# Altium schematic sheet [8]miscellaneous.SchDoc
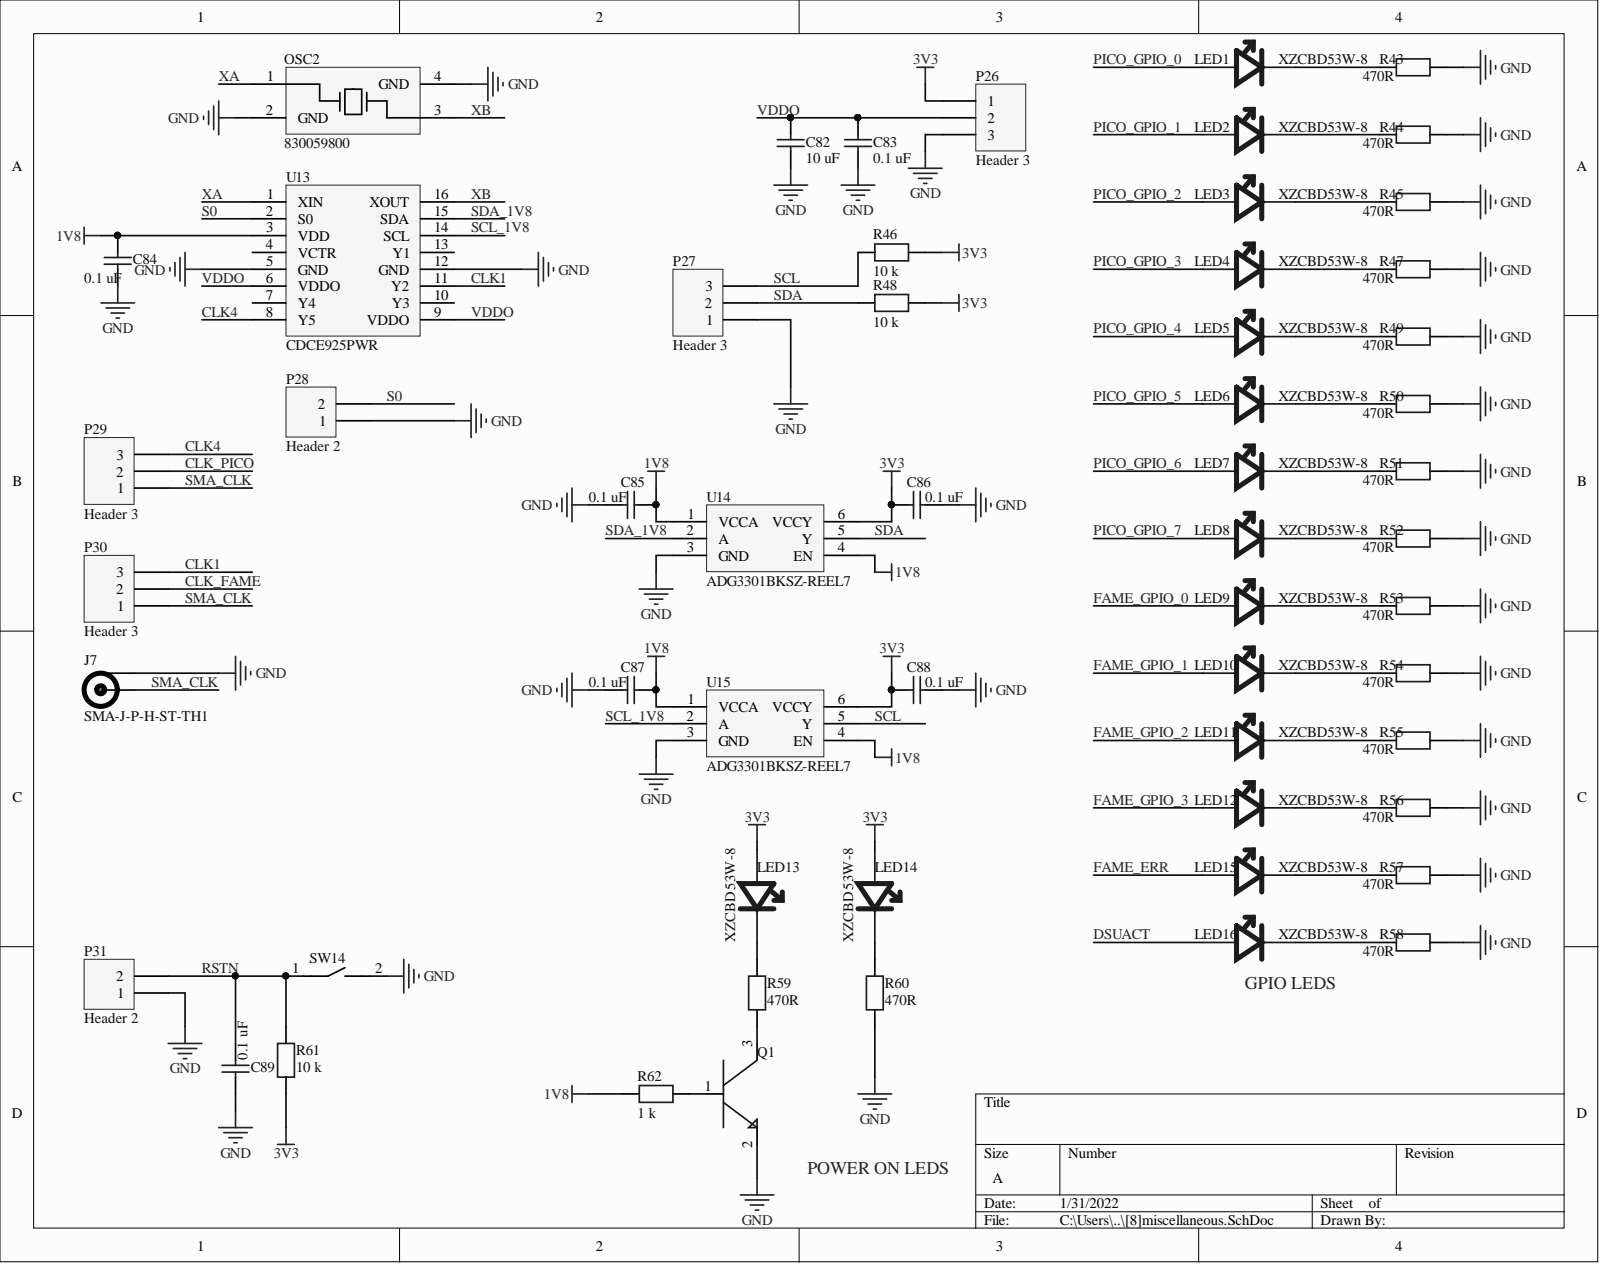
[diagram p0: [8]miscellaneous.SchDoc]

## Parts
C82  value 10 uF  [0603-CAP-ASM]  (hidden comment: Cap)
C83  value 0.1 uF  [0402-CAP-ASM]  (hidden comment: Cap)
C84  value 0.1 uF  [0402-CAP-ASM]  (hidden comment: Cap)
C85  value 0.1 uF  [0402-CAP-ASM]  (hidden comment: Cap)
C86  value 0.1 uF  [0402-CAP-ASM]  (hidden comment: Cap)
C87  value 0.1 uF  [0402-CAP-ASM]  (hidden comment: Cap)
C88  value 0.1 uF  [0402-CAP-ASM]  (hidden comment: Cap)
C89  value 0.1 uF  [0402-CAP-ASM]  (hidden comment: Cap)
J7  SMA-J-P-H-ST-TH1  [SMA-J-P-H-ST-TH1]
LED1  XZCBD53W-8  [0603-LED-ASM]
LED2  XZCBD53W-8  [0603-LED-ASM]
LED3  XZCBD53W-8  [0603-LED-ASM]
LED4  XZCBD53W-8  [0603-LED-ASM]
LED5  XZCBD53W-8  [0603-LED-ASM]
LED6  XZCBD53W-8  [0603-LED-ASM]
LED7  XZCBD53W-8  [0603-LED-ASM]
LED8  XZCBD53W-8  [0603-LED-ASM]
LED9  XZCBD53W-8  [0603-LED-ASM]
LED10  XZCBD53W-8  [0603-LED-ASM]
LED11  XZCBD53W-8  [0603-LED-ASM]
LED12  XZCBD53W-8  [0603-LED-ASM]
LED13  XZCBD53W-8  [0603-LED-ASM]
LED14  XZCBD53W-8  [0603-LED-ASM]
LED15  XZCBD53W-8  [0603-LED-ASM]
LED16  XZCBD53W-8  [0603-LED-ASM]
OSC2  830059800  [32X25 SMD-4 OSC]
P26  Header 3  [Header 1x3 male]
P27  Header 3  [Header 1x3 male]
P28  Header 2  [header 2]
P29  Header 3  [Header 1x3 male]
P30  Header 3  [Header 1x3 male]
P31  Header 2  [header 2]
Q1  CMPT3904G TR PBFREE  [Sot23-3]  (value not drawn)
R43  value 470R  [0402-RES-ASM]  (hidden comment: res)
R44  value 470R  [0402-RES-ASM]  (hidden comment: res)
R45  value 470R  [0402-RES-ASM]  (hidden comment: res)
R46  value 10 k  [0402-RES-ASM]  (hidden comment: res)
R47  value 470R  [0402-RES-ASM]  (hidden comment: res)
R48  value 10 k  [0402-RES-ASM]  (hidden comment: res)
R49  value 470R  [0402-RES-ASM]  (hidden comment: res)
R50  value 470R  [0402-RES-ASM]  (hidden comment: res)
R51  value 470R  [0402-RES-ASM]  (hidden comment: res)
R52  value 470R  [0402-RES-ASM]  (hidden comment: res)
R53  value 470R  [0402-RES-ASM]  (hidden comment: res)
R54  value 470R  [0402-RES-ASM]  (hidden comment: res)
R55  value 470R  [0402-RES-ASM]  (hidden comment: res)
R56  value 470R  [0402-RES-ASM]  (hidden comment: res)
R57  value 470R  [0402-RES-ASM]  (hidden comment: res)
R58  value 470R  [0402-RES-ASM]  (hidden comment: res)
R59  value 470R  [0402-RES-ASM]  (hidden comment: res)
R60  value 470R  [0402-RES-ASM]  (hidden comment: res)
R61  value 10 k  [0402-RES-ASM]  (hidden comment: res)
R62  value 1 k  [0402-RES-ASM]  (hidden comment: res)
SW14  PTS645SK50SMTR92 LFS  [PTS645SK50SMTR92 LFS]  (value not drawn)
U13  CDCE925PWR  [tssop16]
U14  ADG3301BKSZ-REEL7  [SOT363]
U15  ADG3301BKSZ-REEL7  [SOT363]

## Nets
1V8: C84.2 C85.1 C87.1 R62.2 U13.3(VDD) U14.1(VCCA) U14.4(EN) U15.1(VCCA) U15.4(EN)
3V3: C86.1 C88.1 LED13.1 LED14.1 P26.1 R46.2 R48.1 R61.1 U14.6(VCCY) U15.6(VCCY)
GND: C82.1 C83.1 C84.1 C85.2 C86.2 C87.2 C88.2 C89.1 J7.2 OSC2.2(GND) OSC2.4(GND) P26.3 P27.1 P28.1 P31.1 Q1.2 R43.1 R44.1 R45.1 R47.1 R49.1 R50.1 R51.1 R52.1 R53.1 R54.1 R55.1 R56.1 R57.1 R58.1 R60.1 SW14.2 U13.5(GND) U13.12(GND) U14.3(GND) U15.3(GND)
CLK1: P30.3 U13.11(Y2)
CLK4: P29.3 U13.8(Y5)
CLK_FAME: P30.2
CLK_PICO: P29.2
DSUACT: LED16.1
FAME_ERR: LED15.1
FAME_GPIO_0: LED9.1
FAME_GPIO_1: LED10.1
FAME_GPIO_2: LED11.1
FAME_GPIO_3: LED12.1
PICO_GPIO_0: LED1.1
PICO_GPIO_1: LED2.1
PICO_GPIO_2: LED3.1
PICO_GPIO_3: LED4.1
PICO_GPIO_4: LED5.1
PICO_GPIO_5: LED6.1
PICO_GPIO_6: LED7.1
PICO_GPIO_7: LED8.1
RSTN: C89.2 P31.2 R61.2 SW14.1
S0: P28.2 U13.2(S0)
SCL: P27.3 R46.1 U15.5(Y)
SCL_1V8: U13.14(SCL) U15.2(A)
SDA: P27.2 R48.2 U14.5(Y)
SDA_1V8: U13.15(SDA) U14.2(A)
SMA_CLK: J7.1 P29.1 P30.1
VDDO: C82.2 C83.2 P26.2 U13.6(VDDO) U13.9(VDDO)
XA: OSC2.1 U13.1(XIN)
XB: OSC2.3 U13.16(XOUT)
NetLED1_2: LED1.2 R43.2
NetLED2_2: LED2.2 R44.2
NetLED3_2: LED3.2 R45.2
NetLED4_2: LED4.2 R47.2
NetLED5_2: LED5.2 R49.2
NetLED6_2: LED6.2 R50.2
NetLED7_2: LED7.2 R51.2
NetLED8_2: LED8.2 R52.2
NetLED9_2: LED9.2 R53.2
NetLED10_2: LED10.2 R54.2
NetLED11_2: LED11.2 R55.2
NetLED12_2: LED12.2 R56.2
NetLED13_2: LED13.2 R59.2
NetLED14_2: LED14.2 R60.2
NetLED15_2: LED15.2 R57.2
NetLED16_2: LED16.2 R58.2
NetQ1_1: Q1.1 R62.1
NetQ1_3: Q1.3 R59.1

Not connected: U13 4(VCTR) 7(Y4) 10(Y3) 13(Y1)

## Library data of the parts
C82 = Cap: CAP CER 10UF 10V X5R 0603; Component Kind: Standard; Library Name: Miscellaneous Devices.IntLib; Supplier Part Number: 1276-1192-1-ND
C83-C89 = Cap: CAP CER 0.1UF 10V X5R 0402; Component Kind: Standard; Library Name: Miscellaneous Devices.IntLib; Supplier Part Number: 587-1227-1-ND
J7 = SMA-J-P-H-ST-TH1: CONN SMA JACK STR 50 OHM PCB; Component Kind: Standard; Library Name: Mylib..SchLib; Supplier Part Number: SAM8971-ND
LED1-LED16 = LED: LED BLUE CLEAR CHIP SMD; Component Kind: Standard; Library Name: Mylib..SchLib; Supplier Part Number: 1497-1117-1-ND
OSC2 = 7M-25.000MEEQ-T: CRYSTAL 25.0000MHZ 10PF SMD; Component Kind: Standard; Library Name: Mylib..SchLib; Supplier Part Number: 732-830059800CT-ND
P26 P27 P29 P30 = Header 3: CONN HEADER VERT 3POS 2.54MM; Component Kind: Standard; Library Name: Miscellaneous Connectors.IntLib; Supplier Part Number: SAM1031-03-ND
P28 P31 = Header 2: CONN HEADER VERT 2POS; Component Kind: Standard; Library Name: Miscellaneous Connectors.IntLib; Supplier Part Number: SAM1030-01-ND
Q1 = NPN: TRANS NPN 40V 0.2A SOT-23; Component Kind: Standard; Library Name: Mylib..SchLib; Supplier Part Number: 1514-CMPT3904GTRPBFREECT-ND
R43-R45 R47 R49-R60 = RESISTOR: RES SMD 470 OHM 1% 1/16W 0402; Component Kind: Standard; Library Name: Mylib..SchLib; Supplier Part Number: 311-470LRCT-ND
R46 R48 R61 = RESISTOR: RES SMD 10K OHM 5% 1/16W 0402; Component Kind: Standard; Library Name: Mylib..SchLib; Supplier Part Number: 311-10KJRCT-ND
R62 = RESISTOR: RES SMD 1K OHM 1% 1/16W 0402; Component Kind: Standard; Library Name: Mylib..SchLib; Supplier Part Number: YAG1234CT-ND
SW14 = PTS645SK50SMTR92 LFS: SWITCH TACTILE SPST-NO 0.05A 12V; Component Kind: Standard; Library Name: Mylib..SchLib; Supplier Part Number: CKN9087CT-ND
U13 = CDCE925PWR: IC 2PLL PROG VCXO CLK 16-TSSOP; Component Kind: Standard; Library Name: Mylib..SchLib; Supplier Part Number: 296-41230-1-ND
U14 U15 = ADG3301BKSZ-REEL7: IC TRNSLTR BIDIRECTIONAL SC70; Component Kind: Standard; Library Name: Mylib..SchLib; Supplier Part Number: ADG3301BKSZ-REEL7CT-ND
